# Altium schematic sheet GatewayLite-PWR.SchDoc
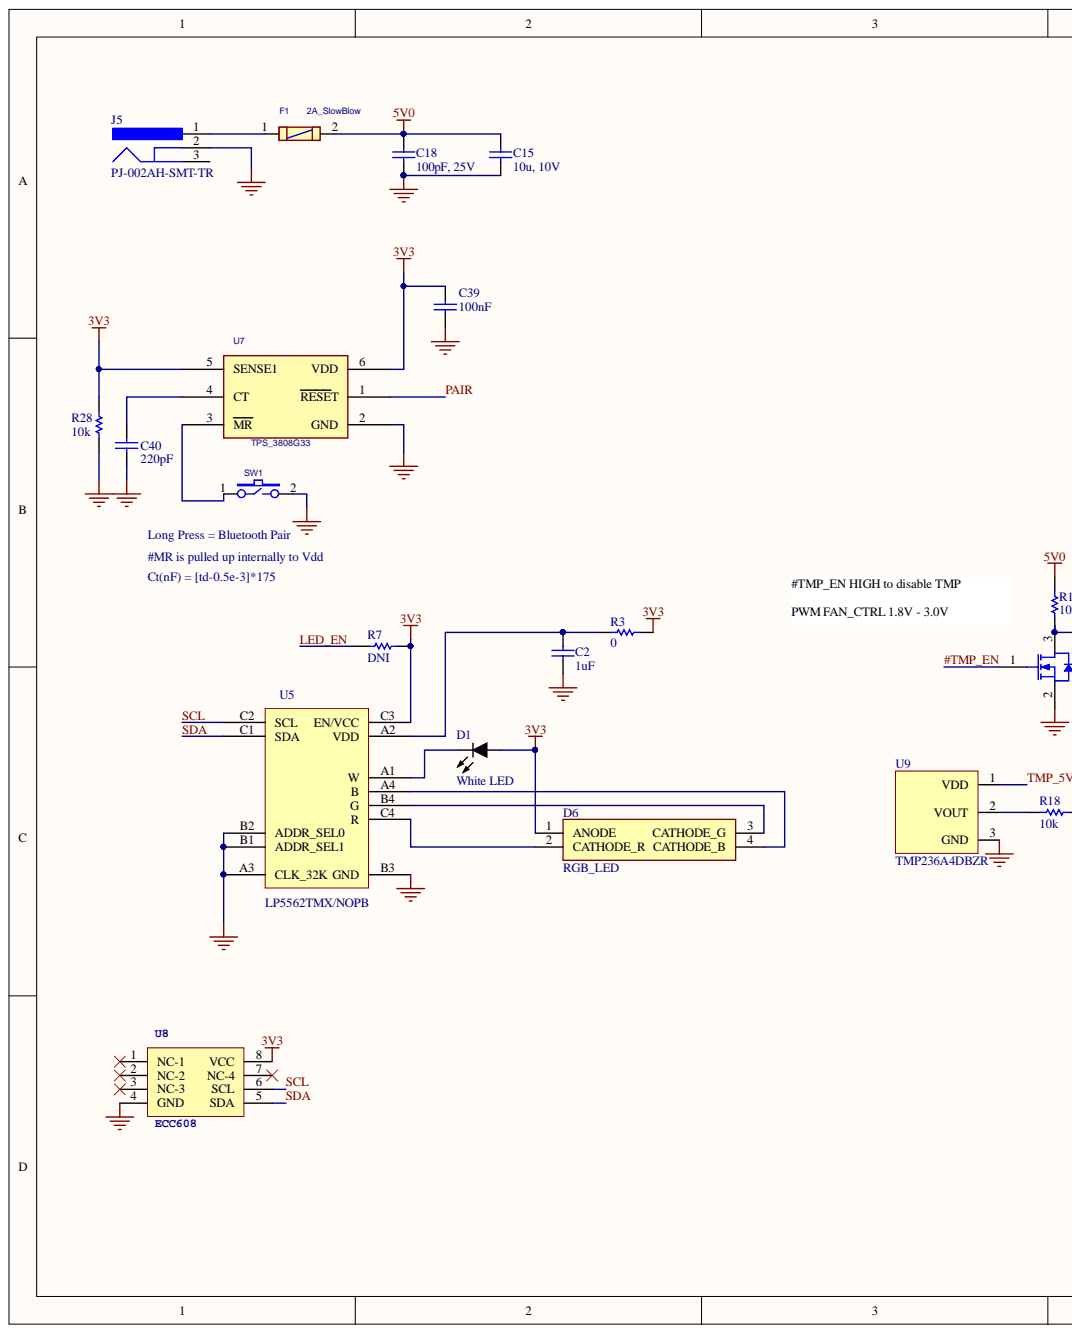
[diagram p0: GatewayLite-PWR.SchDoc, left]
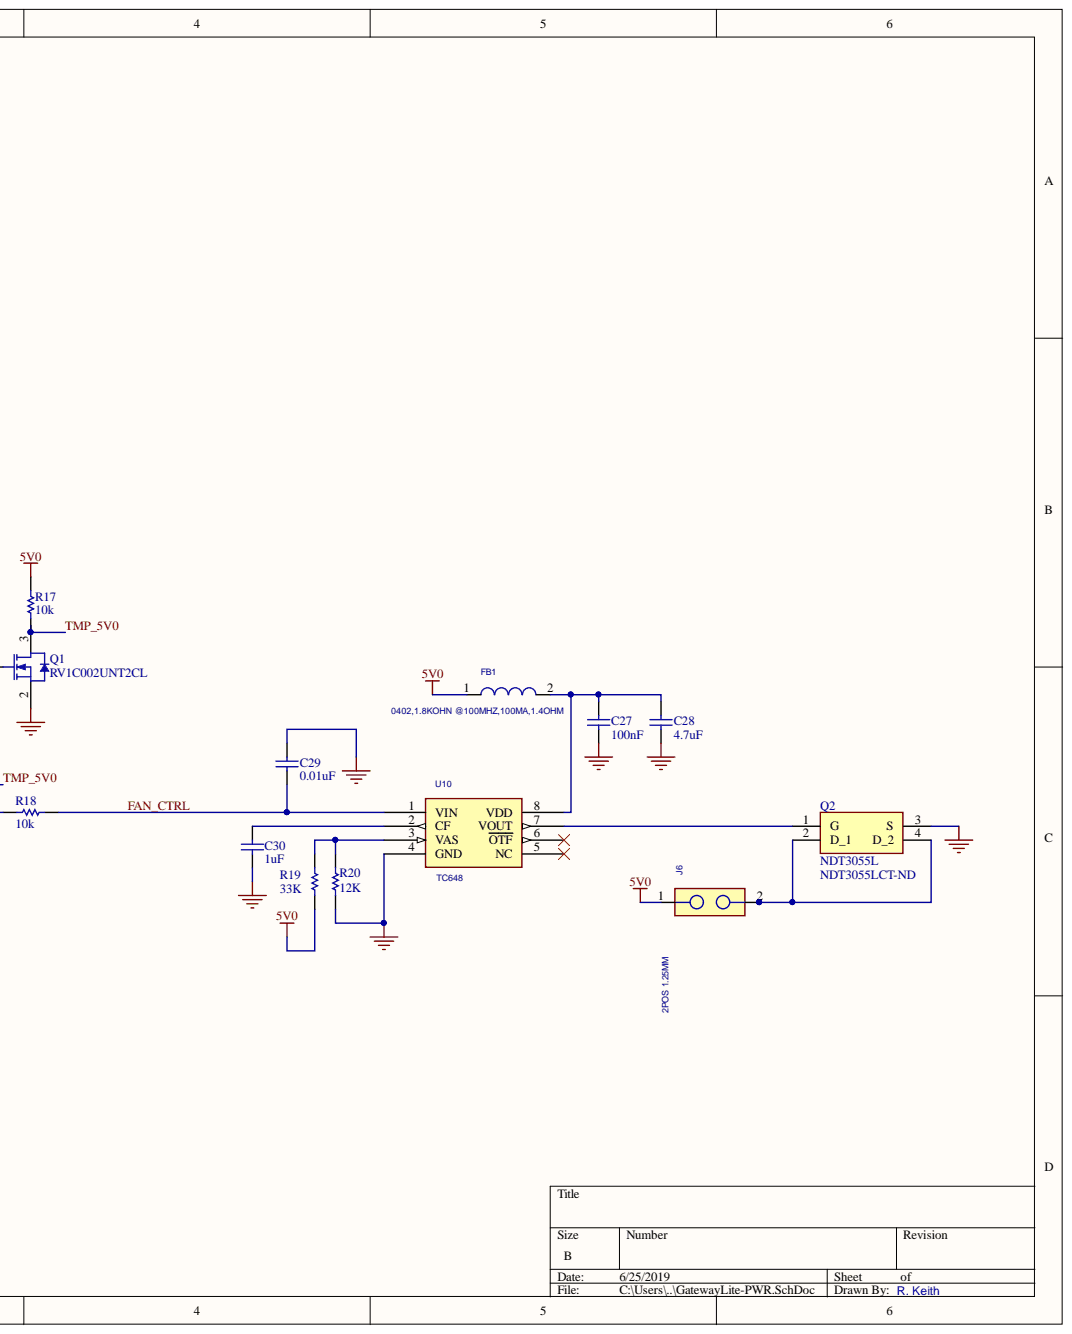
[diagram p1: GatewayLite-PWR.SchDoc, right]

## Parts
C2  1uF  [0402-A]
C15  10u, 10V  Voltage Rating: 10V  [0402]
C18  100pF, 25V  [0603C_24mil]
C27  100nF  [0201C]
C28  4.7uF  [0402]
C29  0.01uF  [0402C]
C30  1uF  [0805_24m]
C39  100nF  [0201C]
C40  220pF  [0201C]
D1  White LED  Color: White  [0603 LED]
D6  RGB_LED  [ASMBKTF00A306]
F1  2A_SlowBlow  [0402]
FB1  0402,1.8KOHN @100MHZ,100MA,1.4OHM  [0402C]
J5  PJ-002AH-SMT-TR  [CUI-PJ-002AH-4_V]
J6  2POS 1.25MM  [CON2_49LS]
Q1  RV1C002UNT2CL  [DFN50P80X33-3N]
Q2  NDT3055L  Supplier Part Number 1: NDT3055LCT-ND  [SOT230P700X180-4N]
R3  0  [0805_24m]
R7  DNI  [0805_24m]
R17  10k  [0201R]
R18  10k  [0201R]
R19  33K  [0201R]
R20  12K  [0201R]
R28  10k  [0201R]
SW1  SWITCH TACTILE SPST-NO 0.05A 12V  [SWITCH TACTILE SPST-NO]  (value not drawn)
U5  LP5562TMX/NOPB  [*BGA12N40P4X3_162X122X68_LP5562TMX/NOPB(Primary)]
U7  TPS_3808G33  [sot23-6]
U8  ECC608  [UFDFN-8_Microchip]
U9  TMP236A4DBZR  [DBZ0003A]
U10  TC648  [SO8-25X75]

## Nets
3V3: C39.2 D1.1 D6.1(ANODE) R3.1 R7.1 R28.1 U5.C3(EN/VCC) U7.5(SENSE1) U7.6(VDD) U8.8(VCC)
5V0: C15.2 C18.2 F1.2 FB1.1 J6.1 R17.2 R19.1
GND: C2.1 C15.1 C18.1 C27.2 C28.1 C29.2 C30.1 C39.1 C40.1 J5.2 Q1.2 Q2.3(S) R20.1 R28.2 SW1.2 U5.A3(CLK_32K) U5.B1(ADDR_SEL1) U5.B2(ADDR_SEL0) U5.B3(GND) U7.2(GND) U8.4(GND) U8.9 U9.3 U10.4(GND)
#TMP_EN: Q1.1
FAN_CTRL: C29.1 R18.2 U10.1(VIN)
LED_EN: R7.2
PAIR: U7.1(RESET)
SCL: U5.C2(SCL) U8.6(SCL)
SDA: U5.C1(SDA) U8.5(SDA)
TMP_5V0: Q1.3 R17.1 U9.1
NetC2_2: C2.2 R3.2 U5.A2(VDD)
NetC27_1: C27.1 C28.2 FB1.2 U10.8(VDD)
NetC30_2: C30.2 U10.2(CF)
NetC40_2: C40.2 U7.4(CT)
NetD1_2: D1.2 U5.A1(W)
NetD6_2: D6.2(CATHODE_R) U5.C4(R)
NetD6_3: D6.3(CATHODE_G) U5.B4(G)
NetD6_4: D6.4(CATHODE_B) U5.A4(B)
NetF1_1: F1.1 J5.1
NetJ6_2: J6.2 Q2.2(D_1) Q2.4(D_2)
NetQ2_1: Q2.1(G) U10.7(VOUT)
NetR18_1: R18.1 U9.2
NetR19_2: R19.2 R20.2 U10.3(VAS)
NetSW1_1: SW1.1 U7.3(MR)

Not connected: J5 3; U8 1(NC-1) 2(NC-2) 3(NC-3) 7(NC-4); U10 5(NC) 6(OTF)

## Library data of the parts
C2 = CMP-126978-4: CAP CER 1UF 25V 10% X5R 0805; Manufacturer: TDK; Manufacturer Part Number: CGB2A1X5R1E105K033BC; Capacitance: 1uF; Case/Package: 0402; Case Code (Imperial): 0402; Case Code (Metric): 1005; Component Kind: Standard; ComponentLink1Description: http://www.farnell.com/datasheets/2292681.pdf?src-supplier=Farnell; ComponentLink1URL: http://www.farnell.com/datasheets/2292681.pdf?src-supplier=Farnell; ComponentLink2Description: http://www.farnell.com/datasheets/2292681.pdf?src-supplier=Newark; ComponentLink2URL: http://www.farnell.com/datasheets/2292681.pdf?src-supplier=Newark; ComponentLink3Description: http://www.farnell.com/datasheets/2009688.pdf?src-supplier=Element14; ComponentLink3URL: http://www.farnell.com/datasheets/2009688.pdf?src-supplier=Element14; ComponentLink4Description: http://product.tdk.com/en/catalog/datasheets/mlcc_commercial_lowprofile_en.pdf?src-supplier=Avnet; ComponentLink4URL: http://product.tdk.com/en/catalog/datasheets/mlcc_commercial_lowprofile_en.pdf?src-supplier=Avnet; ComponentLink5Description: https://product.tdk.com/info/en/catalog/spec/mlccspec_commercial_lowprofile_en.pdf?src-supplier=Digi-Key; ComponentLink5URL: https://product.tdk.com/info/en/catalog/spec/mlccspec_commercial_lowprofile_en.pdf?src-supplier=Digi-Key; Dielectric: X5R; Lead Free: Lead Free; Length: 0.039inch; Max Operating Temperature: 85°C; Min Operating Temperature: -55°C; Mount: Surface Mount; Package Quantity: 1; Packaging: Cut Tape; Pricing 1: 1 = 0.24000, 10 = 0.16600, 100 = 0.08390, 500 = 0.05740, 1000 = 0.05078, 2500 = 0.04858, 5000 = 0.04416 (USD); REACH SVHC: No SVHC; RoHS: Yes; Stock 1: 2242636; Supplier 1: Digi-Key; Supplier Part Number 1: 445-13185-1-ND; Thickness: 0.013inch; Tolerance: 10%; Voltage Rating: 25V; Voltage Rating (DC): 25V; Width: 0.02inch; Library Name: RAK833 LoRaWAN Concentrator Hat.IntLib
C15 = Cap: Capacitor 10uF; Manufacturer: Samsung; Manufacturer Part Number: CL05A106MP5NUNC; Dielectric: X5R; Tolerance: 20%; Capacitance: 10uF; Case/Package: 0402; Case Code (Imperial): 0402; Case Code (Metric): 1005; Component Kind: Standard; ComponentLink1Description: https://datasheet.ciiva.com/25721/cl05a106mp5nunc-25721584.pdf?src-supplier=Digi-Key; ComponentLink1URL: https://datasheet.ciiva.com/25721/cl05a106mp5nunc-25721584.pdf?src-supplier=Digi-Key; ComponentLink2Description: https://datasheet.ciiva.com/26838/getdatasheetpartid-745310-26838170.pdf?src-supplier=Verical; ComponentLink2URL: https://datasheet.ciiva.com/26838/getdatasheetpartid-745310-26838170.pdf?src-supplier=Verical; Contact Plating: Tin; Depth: 0.5mm; Lead Free: Lead Free; Length: 1mm; Library Name: Miscellaneous Devices.SchLib; Max Operating Temperature: 85°C; Min Operating Temperature: -55°C; Mount: Surface Mount; Package Quantity: 1; Packaging: Tape and Reel; Pricing 1: 1 = 0.42000, 10 = 0.29500, 100 = 0.19410, 500 = 0.14376, 1000 = 0.12220, 2500 = 0.11501, 5000 = 0.10782 (USD); Radiation Hardening: No; RoHS: Yes; Signal Integrity: Cap; Simulation: CAP; Stock 1: 5984120; Supplier 1: Digi-Key; Supplier Part Number 1: 1276-1450-1-ND; Termination: Wraparound; Thickness: 0.028inch; Width: 0.02inch
C18 = CAP: Manufacturer: Murata; Manufacturer Part Number: GRM0335C1E101JA01D; Component Kind: Standard; Library Name: GatewayLite.SCHLIB; Part Number: GRM0335C1E101JA01D
C27 C39 = Capacitor, SMD: Capacitor, 0201 SMD; Manufacturer: Murata; Manufacturer Part Number: GRM033R61A104KE15D; Component Kind: Standard; Manufacturer PN: GRM033R61A104KE15D; Supplier: DigiKey; Library Name: LOEC.SchLib
C28 = Cap: Capacitor 4.7uF; Manufacturer: Murata; Manufacturer Part Number: GRM155R60J475ME47D; Component Kind: Standard; LatestRevisionDate: 17-Jul-2002; LatestRevisionNote: Re-released for DXP Platform.; Library Name: Miscellaneous Devices.SchLib; PackageDescription: Radial Cap, Thru-Hole; 2 Leads; 0.3 in Pin Spacing; PackageReference: RAD-0.3; Published: 8-Jun-2000; Signal Integrity: Cap; Simulation: CAP; Value: 4.7uF; Publisher: Altium Limited
C29 = Cap: Manufacturer: AVX Corporation; Manufacturer Part Number: 0402YC103KAT4A; Component Kind: Standard; Description: 0.01uF; LatestRevisionDate: 17-Jul-2002; LatestRevisionNote: Re-released for DXP Platform.; Library Name: Miscellaneous Devices.SchLib; PackageDescription: Radial Cap, Thru-Hole; 2 Leads; 0.3 in Pin Spacing; PackageReference: RAD-0.3; Published: 8-Jun-2000; Signal Integrity: Cap; Simulation: CAP; Value: 0.01uF; Publisher: Altium Limited
C30 = CAP: Manufacturer: AVX Interconnect / Elco; Manufacturer Part Number: 08053D105KAT2A; Component Kind: Standard; Library Name: GatewayLite.SCHLIB; Part Number: 08053D105KAT2A
C40 = Capacitor, SMD: Capacitor, 0201 SMD; Manufacturer: TDK; Manufacturer Part Number: CGA1A2X7R1E221M030BA; Component Kind: Standard; Manufacturer PN: CGA1A2X7R1E221M030BA; Supplier: DigiKey; Library Name: LOEC.SchLib
D1 = LED 0603: Manufacturer: Citizen; Manufacturer Part Number: CL-194S-WS-SD-T; Correlated Color Temperature (CCT): x=0.34/y=0.386; Forward Voltage: 2.9V; Lens Style: Rectangular; Luminous Intensity: 260mcd; Max Power Dissipation: 76mW; Number of LEDs: 1; Test Current: 5mA; Case/Package: 0603; Case Code (Imperial): 0603; Case Code (Metric): 1608; Component Kind: Standard; ComponentLink1Description: http://ce.citizen.co.jp/up_img/product/WBtpQ6f1JXom/CL-194S.pdf?src-supplier=Digi-Key; ComponentLink1URL: http://ce.citizen.co.jp/up_img/product/WBtpQ6f1JXom/CL-194S.pdf?src-supplier=Digi-Key; ComponentLink2Description: http://ce.citizen.co.jp/productse/info.php?no=63&src-supplier=Avnet+Asia; ComponentLink2URL: http://ce.citizen.co.jp/productse/info.php?no=63&src-supplier=Avnet+Asia; Description: LED 0603; Height: 0.3mm; Length: 1.6mm; Max Operating Temperature: 80°C; Min Operating Temperature: -25°C; Mount: Surface Mount; Packaging: Tape and Reel; Pricing 1: 1 = 0.50000, 10 = 0.35200, 25 = 0.30560, 100 = 0.23500, 250 = 0.19092, 500 = 0.14098, 1000 = 0.11748, 2500 = 0.10573 (USD); RoHS: Yes; Stock 1: 12259; Supplier 1: Digi-Key; Supplier Part Number 1: 1642-1490-1-ND; Width: 0.8mm; Library Name: LOEC.SchLib
D6 = ASMB-KTF0-0A306_1: Common Anode RGB LED; Manufacturer: Broadcom; Color: Blue; Dominant Wavelength: 625nm; Forward Voltage: 3.1V; Lens Style: Circular; Luminous Intensity: 1.6cd; Number of LEDs: 3; Viewing Angle: 115°; Component Kind: Standard; ComponentLink1Description: http://uk.rs-online.com/webdocs/133c/0900766b8133c17d.pdf?src-supplier=RSComponents; ComponentLink1URL: http://uk.rs-online.com/webdocs/133c/0900766b8133c17d.pdf?src-supplier=RSComponents; ComponentLink2Description: http://www.farnell.com/datasheets/2343968.pdf?src-supplier=Farnell; ComponentLink2URL: http://www.farnell.com/datasheets/2343968.pdf?src-supplier=Farnell; ComponentLink3Description: http://www.avagotech.com/docs/AV02-4186EN?src-supplier=Avnet; ComponentLink3URL: http://www.avagotech.com/docs/AV02-4186EN?src-supplier=Avnet; ComponentLink4Description: https://docs.broadcom.com/docs/AV02-4186EN?src-supplier=Digi-Key; ComponentLink4URL: https://docs.broadcom.com/docs/AV02-4186EN?src-supplier=Digi-Key; ComponentLink5Description: http://www.farnell.com/datasheets/1801563.pdf?src-supplier=Element14; ComponentLink5URL: http://www.farnell.com/datasheets/1801563.pdf?src-supplier=Element14; Contact Plating: Silver; Datasheet Link: https://componentsearchengine.com/Datasheets/1/ASMB-KTF0-0A306.pdf; Height: 2.1mm; Library Name: GatewayLite.SCHLIB; Manufacturer Part Number: ASMB-KTF0-0A306; Max Operating Temperature: 85°C; Min Operating Temperature: -40°C; Mount: Surface Mount; Mouser Part Number: 630-ASMB-KTF0-0A306; Mouser Price/Stock: https://www.mouser.com/Search/Refine.aspx?Keyword=630-ASMB-KTF0-0A306; Number of Pins: 4; Packaging: Cut Tape; Pricing 1: 1 = 0.63000, 10 = 0.49400, 25 = 0.41120, 100 = 0.35430, 250 = 0.31636, 500 = 0.27206, 1000 = 0.21006 (USD); REACH SVHC: No SVHC; RoHS: Yes; Stock 1: 130270; Supplier 1: Digi-Key; Supplier Part Number 1: 516-3279-1-ND
F1 = FUSE_4A_QUICK: Manufacturer: Panasonic; Manufacturer Part Number: ERB-RD2R00X; Approvals: UL; Body Material: Alumina; Current: 2A; DC Cold Resistance: 53mR; Fuse Type: Board Mount (Cartridge Style Excluded); Max Voltage Rating (DC): 32V; Case/Package: 0402; Case Code (Imperial): 0402; Case Code (Metric): 1005; Component Kind: Standard; ComponentLink1Description: https://datasheet.ciiva.com/25619/0900766b810c2f76-25619800.pdf?src-supplier=RSComponents; ComponentLink1URL: https://datasheet.ciiva.com/25619/0900766b810c2f76-25619800.pdf?src-supplier=RSComponents; ComponentLink2Description: https://datasheet.ciiva.com/299/afa0000ce6-299077.pdf?src-supplier=Digi-Key; ComponentLink2URL: https://datasheet.ciiva.com/299/afa0000ce6-299077.pdf?src-supplier=Digi-Key; ComponentLink3Description: https://datasheet.ciiva.com/299/afa0000ce6-299078.pdf?src-supplier=Digi-Key; ComponentLink3URL: https://datasheet.ciiva.com/299/afa0000ce6-299078.pdf?src-supplier=Digi-Key; ComponentLink4Description: https://datasheet.ciiva.com/11337/afa0000ce6-11337814.pdf?src-supplier=Avnet; ComponentLink4URL: https://datasheet.ciiva.com/11337/afa0000ce6-11337814.pdf?src-supplier=Avnet; ComponentLink5Description: https://datasheet.ciiva.com/299/afa0000ce6-299079.pdf?src-supplier=Digi-Key; ComponentLink5URL: https://datasheet.ciiva.com/299/afa0000ce6-299079.pdf?src-supplier=Digi-Key; ComponentLink6Description: https://datasheet.ciiva.com/30017/afa0000ce6-30017404.pdf?src-supplier=Avnet; ComponentLink6URL: https://datasheet.ciiva.com/30017/afa0000ce6-30017404.pdf?src-supplier=Avnet; Current Rating: 2A; Depth: 0.5mm; Fuse Current: 2A; Height: 0.39mm; Length: 1mm; Max Operating Temperature: 125°C; Min Operating Temperature: -40°C; Mount: Surface Mount; Number of Pins: 2; Package Quantity: 10000; Packaging: Tape and Reel; Pricing 1: 1 = 0.27000, 5 = 0.26200, 10 = 0.25000, 50 = 0.22340, 100 = 0.20790, 250 = 0.18480, 500 = 0.15400, 1000 = 0.14630, 5000 = 0.11550 (USD); Radiation Hardening: No; REACH SVHC: No SVHC; RoHS: Yes; Stock 1: 41562; Supplier 1: Digi-Key; Supplier Part Number 1: P15123CT-ND; Voltage Rating: 32V; Voltage Rating (DC): 32V; Width: 0.5mm
FB1 = FERRITE_180_800_0805: Ferrite Bead 150 Ohm 800mA; Manufacturer: Murata; Manufacturer Part Number: BLM15BD182SN1D; Component Kind: Standard; Library Name: GatewayLite.SCHLIB
J5 = CMP-2000-06896-1: DC Power Jack, Right Angle, -25 to 85 degC, 4-Pin 14.8 x 9 x 11 mm SMD, RoHS, Tape and Reel; Manufacturer: CUIInc.; Brand: CUI; Current Rating: 5A; Inside Contact Diameter: 2mm; Insulation Resistance: 100MOhms; Outside Contact Diameter: 6.5mm; Voltage Rating: 24V; Component Kind: Standard; Connector Type: DCPowerJack; Contact Gender: Male; Contact Material: Brass; Contact Plating: Nickel; Datasheet URL: http://www.cui.com/product/resource/pj-002ah-smt.pdf; Datasheet Version: Rev. 1.03, 11/2012; Factory Pack Quantity: 350; Flammability Rating: UL94V-0; Housing Material: Polyamide(PA); Library Name: Altium Content Vault; Manufacturer Part Number: PJ-002AH-SMT-TR; Manufacturer URL: http://www.cui.com/; Mounting Angle: Right; Mounting Style: SMD/SMT; Mounting Technology: SurfaceMount; Operating Temperature Range: -25Cto+85C; Package Description: 4-Pin Surface Mount Device, Body 14.8 x 9 mm, Pitch 6.1 mm; Package Reference: PJ-002AH; Packaging: Reel; PartNumber: PJ-002AH; Product: Jacks; Product Category: DCPowerConnectors; RoHS: True; Termination Style: SMD/SMT
J6 = HDR_1x1_M_0.1: Manufacturer: Molex; Manufacturer Part Number: 0530470210; Component Kind: Standard
Q1 = RV1C002UNT2CL: RV1C002UN Series 20 V 150 mA 2 Ohm Surface Mount Small Signal Mosfet - VML0806; Manufacturer: Rohm; Continuous Drain Current (ID): 150mA; Drain to Source Breakdown Voltage: 20V; Drain to Source Resistance: 2R; Fall Time: 25ns; Gate to Source Voltage (Vgs): 8V; Input Capacitance: 12pF; Max Power Dissipation: 100mW; Rise Time: 4ns; Turn-Off Delay Time: 12ns; Turn-On Delay Time: 3ns; Case/Package: SMD/SMT; Component Kind: Standard; ComponentLink1Description: https://datasheet.ciiva.com/17324/rv1c002un-17324781.pdf?src-supplier=Avnet+Europe; ComponentLink1URL: https://datasheet.ciiva.com/17324/rv1c002un-17324781.pdf?src-supplier=Avnet+Europe; Drain to Source Voltage (Vdss): 20V; Lead Free: Lead Free; Library Name: GatewayLite.SCHLIB; Manufacturer Part Number: RV1C002UNT2CL; Max Operating Temperature: 150°C; Min Operating Temperature: -55°C; Mount: Surface Mount; Number of Pins: 3; Packaging: Tape and Reel; Power Dissipation: 100mW; Pricing 1: 1 = 0.34000, 10 = 0.31000, 100 = 0.17370, 500 = 0.09308, 1000 = 0.06329 (USD); Radiation Hardening: No; Rds On Max: 2R; RoHS: Yes; Stock 1: 190324; Supplier 1: Digi-Key; Supplier Part Number 1: RV1C002UNT2CLCT-ND
Q2 = NDT3055L: Transistor; Manufacturer: ON Semiconductor / Fairchild; Continuous Drain Current (ID): 4A; Current: 32A; Current Rating: 3.5A; Drain to Source Breakdown Voltage: 60V; Drain to Source Resistance: 70mR; Element Configuration: Single; Fall Time: 7ns; Gate to Source Voltage (Vgs): 20V; Input Capacitance: 345pF; Max Power Dissipation: 3W; Nominal Vgs: 1.6V; Number of Elements: 1; On-State Resistance: 100mR; Rise Time: 7.5ns; Turn-Off Delay Time: 20ns; Turn-On Delay Time: 5ns; Voltage: 40V; Case/Package: SOT-223; Component Kind: Standard; ComponentLink1Description: https://datasheet.ciiva.com/932/ndt3055l-932336.pdf?src-supplier=Digi-Key; ComponentLink1URL: https://datasheet.ciiva.com/932/ndt3055l-932336.pdf?src-supplier=Digi-Key; ComponentLink2Description: https://datasheet.ciiva.com/6039/238543-6039936.pdf?src-supplier=Farnell; ComponentLink2URL: https://datasheet.ciiva.com/6039/238543-6039936.pdf?src-supplier=Farnell; ComponentLink3Description: https://datasheet.ciiva.com/26943/getdatasheetpartid-110691-26943011.pdf?src-supplier=Verical; ComponentLink3URL: https://datasheet.ciiva.com/26943/getdatasheetpartid-110691-26943011.pdf?src-supplier=Verical; ComponentLink4Description: https://datasheet.ciiva.com/4441/ndt3055l-96237-4441015.pdf?src-supplier=Mouser; ComponentLink4URL: https://datasheet.ciiva.com/4441/ndt3055l-96237-4441015.pdf?src-supplier=Mouser; ComponentLink5Description: https://datasheet.ciiva.com/19459/874875-19459511.pdf?src-supplier=Jameco; ComponentLink5URL: https://datasheet.ciiva.com/19459/874875-19459511.pdf?src-supplier=Jameco; ComponentLink6Description: https://datasheet.ciiva.com/932/ndt3055l-932337.pdf?src-supplier=Digi-Key; ComponentLink6URL: https://datasheet.ciiva.com/932/ndt3055l-932337.pdf?src-supplier=Digi-Key; ComponentLink7Description: https://datasheet.ciiva.com/932/ndt3055l-932338.pdf?src-supplier=Digi-Key; ComponentLink7URL: https://datasheet.ciiva.com/932/ndt3055l-932338.pdf?src-supplier=Digi-Key; ComponentLink8Description: https://datasheet.ciiva.com/22671/238543-22671879.pdf?src-supplier=Element14; ComponentLink8URL: https://datasheet.ciiva.com/22671/238543-22671879.pdf?src-supplier=Element14; ComponentLink9Description: https://datasheet.ciiva.com/22722/238543-22722263.pdf?src-supplier=Element14; ComponentLink9URL: https://datasheet.ciiva.com/22722/238543-22722263.pdf?src-supplier=Element14; ComponentLink10Description: https://datasheet.ciiva.com/26140/ndt3055l-26140263.pdf?src-supplier=Avnet+Europe; ComponentLink10URL: https://datasheet.ciiva.com/26140/ndt3055l-26140263.pdf?src-supplier=Avnet+Europe; ComponentLink11Description: https://datasheet.ciiva.com/22671/238543-22671880.pdf?src-supplier=Element14; ComponentLink11URL: https://datasheet.ciiva.com/22671/238543-22671880.pdf?src-supplier=Element14; Contact Plating: Tin; Drain to Source Voltage (Vdss): 60V; Dual Supply Voltage: 60V; Height: 1.6mm; Lead Free: Lead Free; Length: 6.5mm; Library Name: GatewayLite.SCHLIB; Manufacturer Part Number: NDT3055L; Max Operating Temperature: 150°C; Min Operating Temperature: -65°C; Mount: Surface Mount; Number of Pins: 4; Package Quantity: 1; Packaging: Tape and Reel; Power Dissipation: 3W; Pricing 1: 1 = 0.99000, 10 = 0.86200, 100 = 0.66500, 500 = 0.49262, 1000 = 0.39410 (USD); Radiation Hardening: No; Rds On Max: 100mR; REACH SVHC: No SVHC; RoHS: Yes; Stock 1: 88108; Supplier 1: Digi-Key; Threshold Voltage: 1.6V; Voltage Rating (DC): 60V; Width: 6.7mm
R3 = RES: Manufacturer: Yageo; Manufacturer Part Number: RC0805FR-070RL; Component Kind: Standard; Library Name: GatewayLite.SCHLIB; Part Number: RC0805FR-070RL
R7 = RES: Manufacturer: Yageo; Part Number: RC0805FR-070RL; Component Kind: Standard; Library Name: GatewayLite.SCHLIB; Manufacturer Part Number: DNI
R17 R18 R28 = Resistor, SMD: Manufacturer: Panasonic; Manufacturer Part Number: ERA-1AEB103C; Component Kind: Standard; Description: Resistor, SMD; Manufacturer PN: ERA-1AEB103C; Supplier: DigiKey; Library Name: LOEC.SchLib
R19 = RES: Manufacturer: Vishay Dale; Manufacturer Part Number: CRCW020133K0FKED; Component Kind: Standard; Library Name: GatewayLite.SCHLIB; Manufacturer PN: CRCW020133K0FKED; Part Number: CRCW020133K0FKED
R20 = RES: Manufacturer: Vishay Dale; Manufacturer Part Number: CRCW020112K0FKED; Component Kind: Standard; Library Name: GatewayLite.SCHLIB; Manufacturer PN: CRCW020112K0FKED; Part Number: CRCW020112K0FKED
SW1 = SWITCH TACTILE SPST-NO 0.05A 12V: Manufacturer: E-Switch; Manufacturer Part Number: TL1100CF160Q; Component Kind: Standard; Manufacturer PN: TL1100CF160Q; Library Name: Gateway Starman lib.SCHLIB
U5 = LP5562TMX/NOPB: Four-Channel LED Driver with Programmable Lighting Sequences 12-WCSP -40 to 85; Manufacturer: Texas Instruments; Dimming: I²C; Frequency: 558kHz; Max Input Voltage: 5.5V; Max Output Current: 25.5mA; Max Supply Current: 1mA; Min Input Voltage: 2.7V; Nominal Input Voltage (DC): 3.6V; Number of Channels: 4; Switching Frequency: 558Hz; Availability: Unavailable; Component Kind: Standard; ComponentLink1Description: https://datasheet.ciiva.com/29602/lp5562-29602908.pdf?src-supplier=Digi-Key; ComponentLink1URL: https://datasheet.ciiva.com/29602/lp5562-29602908.pdf?src-supplier=Digi-Key; Lead Free: Lead Free; Library Name: GatewayLite.SCHLIB; Manufacturer Part Number: LP5562TMX/NOPB; Max Operating Temperature: 85°C; Max Supply Voltage: 5.5V; MF: Texas Instruments; Min Operating Temperature: -40°C; Mount: Surface Mount; Number of LEDs: 4; Number of Outputs: 4; Number of Pins: 12; Output Current per Channel: 25.5mA; Output Voltage: 5.5V; Package: DSBGA-12 Texas Instruments; Package Quantity: 3000; Packaging: Tape and Reel; Price: None; Pricing 1: 1 = 1.14000, 10 = 1.01500, 100 = 0.79120, 500 = 0.65364, 1000 = 0.51603 (USD); Quiescent Current: 1mA; Radiation Hardening: No; RoHS: Yes; SnapEDA_Link: https://www.snapeda.com/parts/LP5562TMX/NOPB/Texas%20Instruments/view-part/?ref=snap; Stock 1: 109569; Supplier 1: Digi-Key; Supplier Part Number 1: 296-39061-1-ND
U7 = PWR_TPS3808G09DBVR_SOT23_0: Manufacturer: Texas Instruments; Manufacturer Part Number: TPS3808G33DBVR; Component Kind: Standard; Library Name: GatewayLite.SCHLIB; Manufacturer PN: TPS3808G33DBVR
U8 = Atmel ECC608: Atmel ECC608 Crypto Authentucation - Security; Manufacturer: Microchip; Manufacturer Part Number: ATECC608A-MAHDA-T; Value: IC AUTHENTICATION CHIP 8UDFN; Component Kind: Standard; Pricing 1: 1 = 0.75000, 25 = 0.69200 (USD); RoHS: No; Stock 1: 15970; Supplier: Digikey; Supplier Part Number 1: ATECC608A-MAHDA-T; Library Name: RAK833 LoRaWAN Concentrator Hat.SCHLIB
U9 = TMP236A4DBZR: Precision analog output temperature sensor 3-SOT-23 -10 to 125; Manufacturer: Texas Instruments; Component Kind: Standard; ComponentLink1Description: https://octopart.com/click/track?ak=2673a2b2&ct=datasheets&sig=019b186&at=physicalpart&sid=370&ppid=94966382&hlid=26687270&src-supplier=TI+store; ComponentLink1URL: https://octopart.com/click/track?ak=2673a2b2&ct=datasheets&sig=019b186&at=physicalpart&sid=370&ppid=94966382&hlid=26687270&src-supplier=TI+store; ComponentLink2Description: http://sigma.octopart.com/80351974/technical_drawing/Texas-Instruments-TMP236A4DBZR.pdf?src-supplier=TI+store; ComponentLink2URL: http://sigma.octopart.com/80351974/technical_drawing/Texas-Instruments-TMP236A4DBZR.pdf?src-supplier=TI+store; Library Name: GatewayLite.SCHLIB; Manufacturer Part Number: TMP236A4DBZR; Pricing 1: 1 = 0.74000, 10 = 0.61100, 25 = 0.53640, 100 = 0.37950, 250 = 0.34652, 500 = 0.33000, 1000 = 0.28875 (USD); Stock 1: 2984; Supplier 1: Digikey; Supplier Part Number 1: 296-51841-1-ND
U10 = TC648_0: Manufacturer: Micrel; Manufacturer Part Number: TC648VOA713; Component Kind: Standard; Library Name: GatewayLite.SCHLIB; Manufacturer PN: TC648VOA713
